# Altium schematic sheet Schematic("All Documents",Physical)
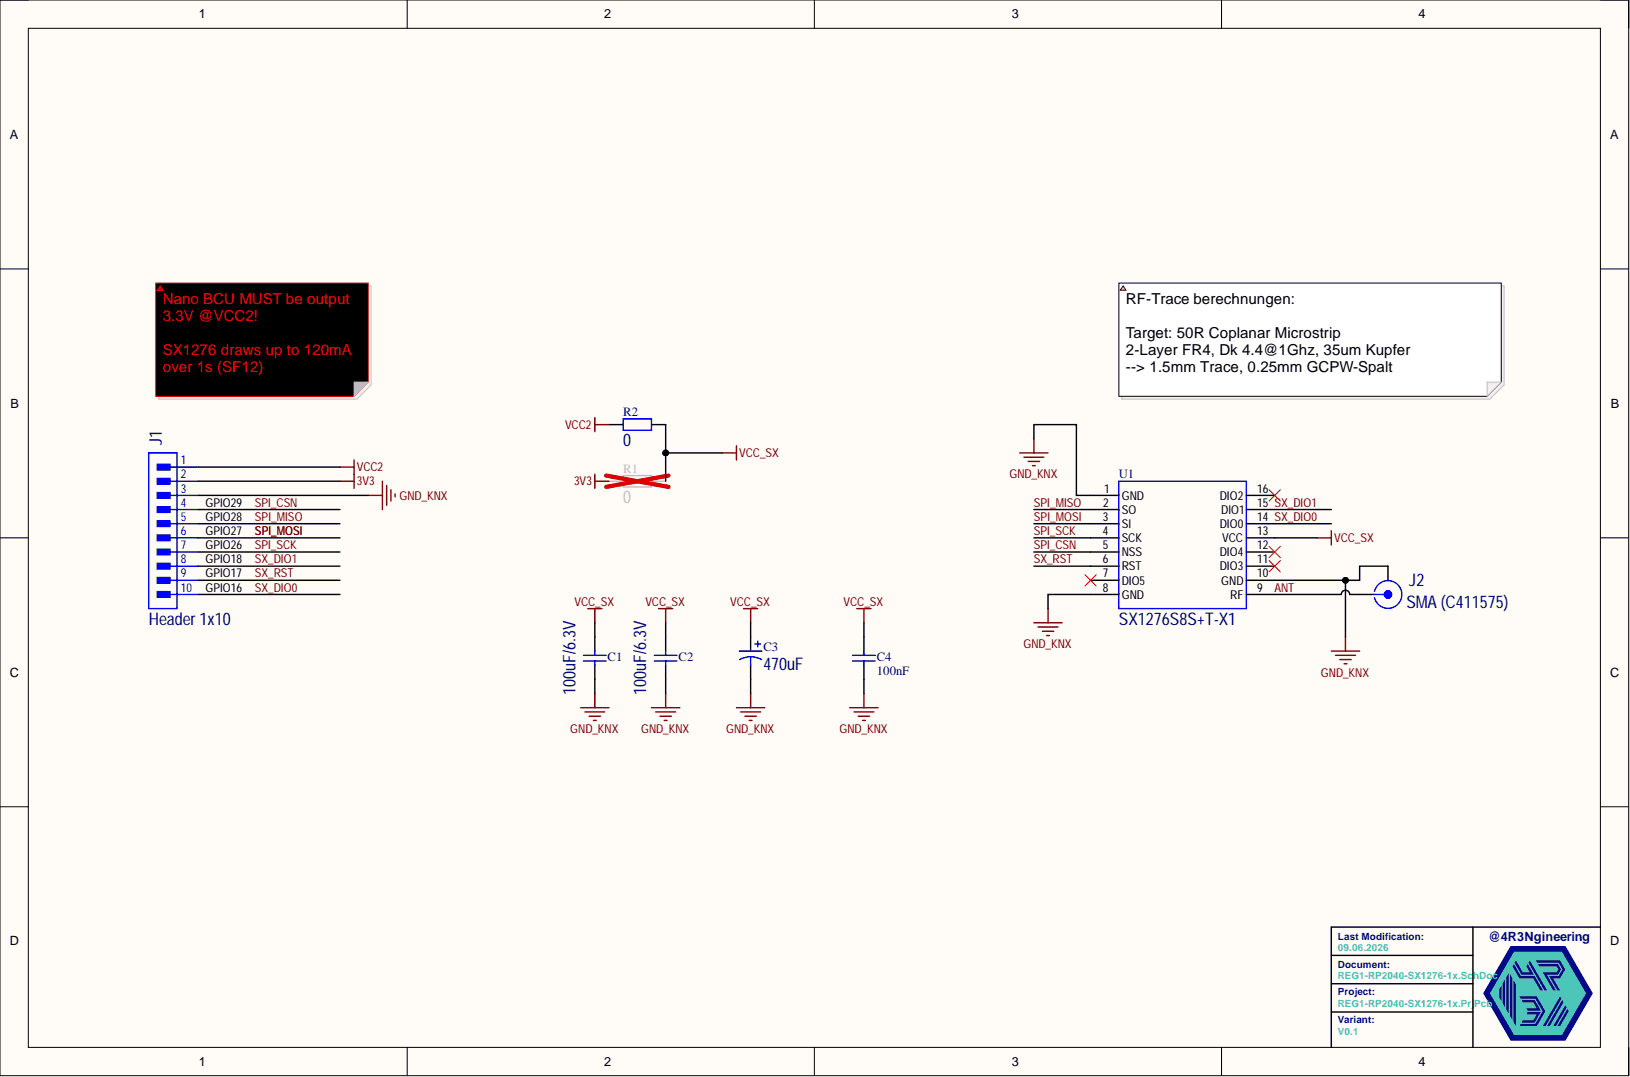
[diagram p0: Schematic("All Documents",Physical)]

## Parts
C1  100uF/6.3V  [C1206]
C2  100uF/6.3V  [C1206]
C3  470uF  [CAPR-5mm]
C4  100nF  [C0603]
J1  Header 1x10  [Header 1x10]
J2  SMA (C411575)  [ANT-TH_KH-SMA-K513-G]
R1  0  [R1206]
R2  0  [R1206]
U1  SX1276S8S+T-X1  [WIRELM-SMD_SX1278S165SY-T-X1]

## Nets
3V3: J1.2 R1.1
GND_KNX: C1.1 C2.1 C3.1 C4.1 J1.3 J2.M U1.1(GND) U1.8(GND) U1.10(GND)
VCC2: J1.1 R2.1
VCC_SX: C1.2 C2.2 C3.2 C4.2 R1.2 R2.2 U1.13(VCC)
ANT: J2.1 U1.9(RF)
SPI_CSN: J1.4 U1.5(NSS)
SPI_MISO: J1.5 U1.2(SO)
SPI_MOSI: J1.6 U1.3(SI)
SPI_SCK: J1.7 U1.4(SCK)
SX_DIO0: J1.10 U1.14(DIO0)
SX_DIO1: J1.8 U1.15(DIO1)
SX_RST: J1.9 U1.6(RST)
NetU1_7: U1.7(DIO5)
NetU1_11: U1.11(DIO3)
NetU1_12: U1.12(DIO4)
NetU1_16: U1.16(DIO2)

## Library data of the parts
C1 C2 C4 = Cap: Capacitor; Component Kind: Standard; Signal Integrity: Cap; Simulation: CAP; Library Name: cadLib.IntLib
  C4: LatestRevisionDate: 17-Jul-2002; LatestRevisionNote: Re-released for DXP Platform.; PackageReference: RAD-0.3; Published: 8-Jun-2000; Value: 100nF; Publisher: Altium Limited
C3 = Cap Pol: Polarized Capacitor (Axial); Component Kind: Standard; LatestRevisionDate: 17-Jul-2002; LatestRevisionNote: Re-released for DXP Platform.; PackageDescription: Polarized Capacitor; 2 Leads; PackageReference: POLAR0.8; Published: 8-Jun-2000; Signal Integrity: Cap Pol; Simulation: CAP; Library Name: cadLib.IntLib; Publisher: Altium Limited
J1 = PinHeader 1x10 RM2.54: Component Kind: Standard; Description: Header 1x10; Library Name: cadLib_connectors.IntLib
J2 = SMA Coax: Component Kind: Standard; Library Name: cadLib_connectors.IntLib
R1 R2 = Res: Resistor; Component Kind: Standard; Signal Integrity: Res; Simulation: RESISTOR; Library Name: cadLib.IntLib
U1 = SX1276S8S+T-X1: Component Kind: Standard; Description: SX1276S8S+T-X1; Library Name: cadLib.IntLib
